# Altium schematic sheet Loads.SchDoc
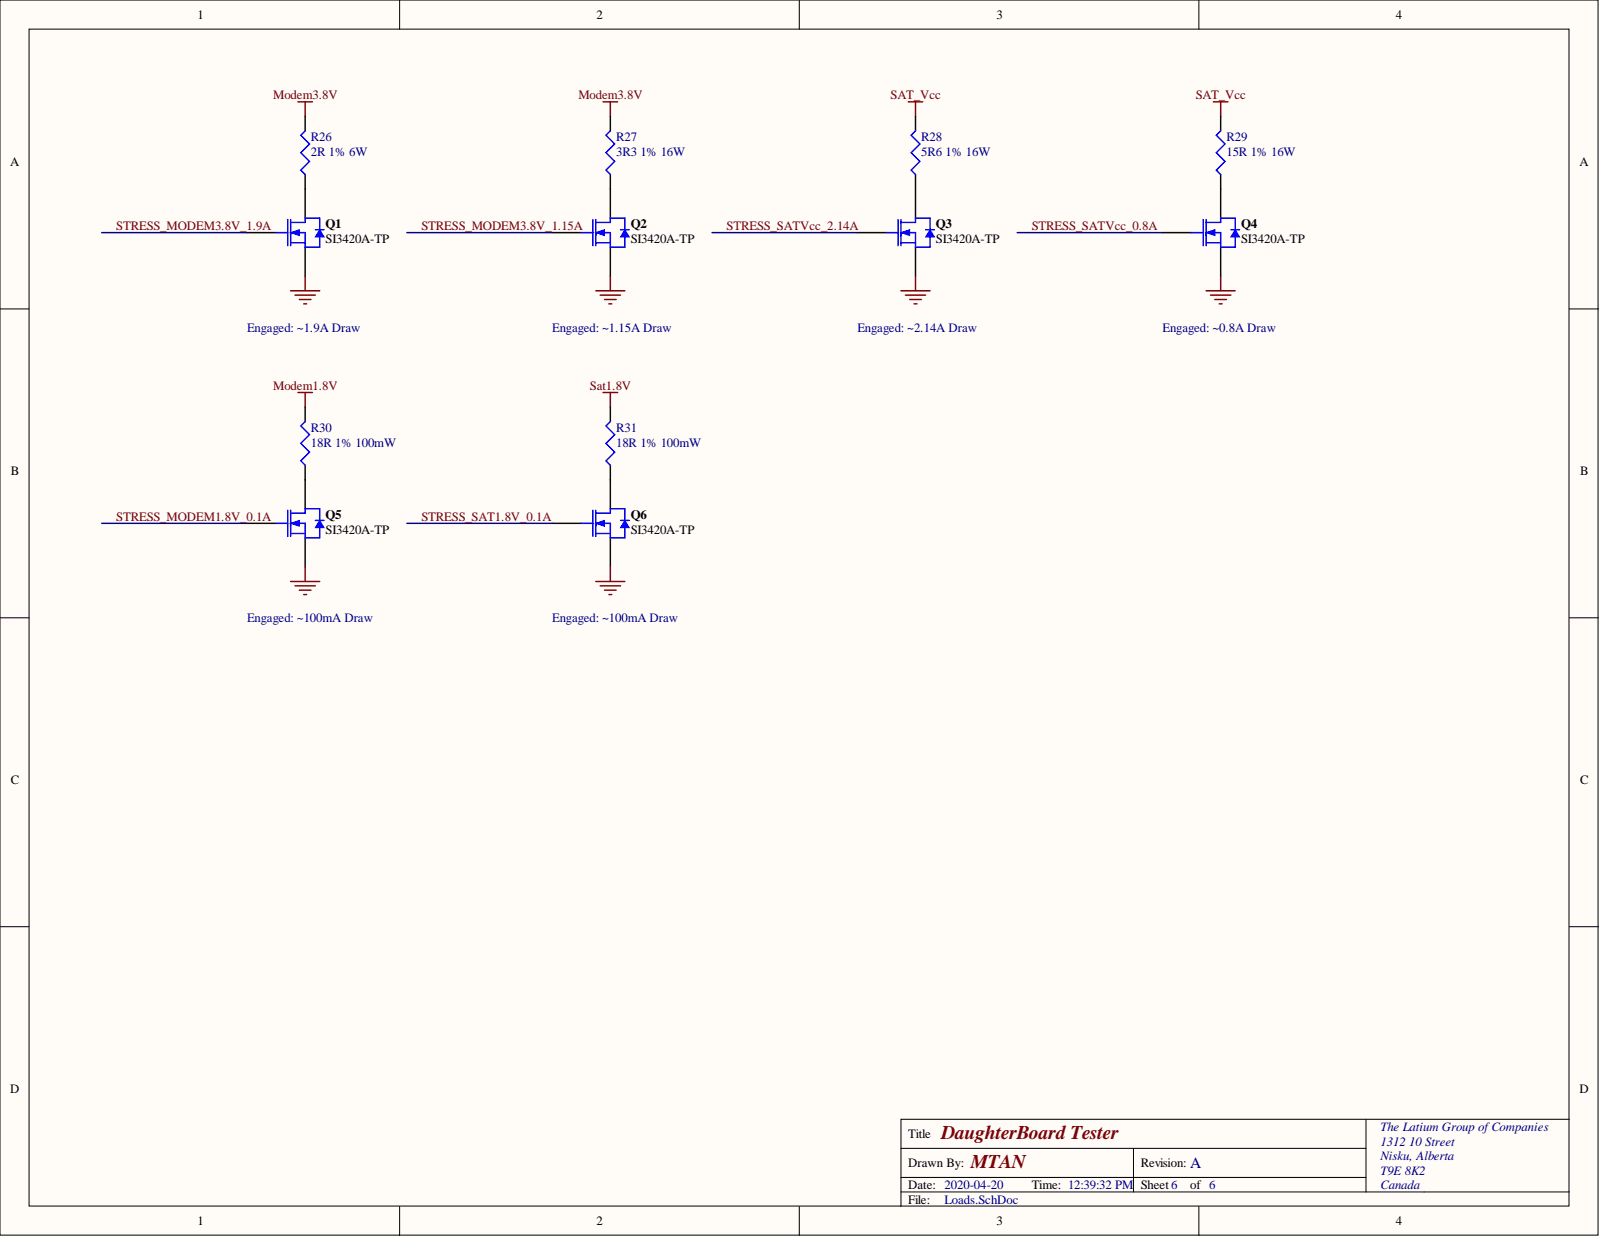
[diagram p0: Loads.SchDoc]

## Parts
Q1  SI3420A-TP  [INF-PG-SOT23_L]
Q2  SI3420A-TP  [INF-PG-SOT23_L]
Q3  SI3420A-TP  [INF-PG-SOT23_L]
Q4  SI3420A-TP  [INF-PG-SOT23_L]
Q5  SI3420A-TP  [INF-PG-SOT23_L]
Q6  SI3420A-TP  [INF-PG-SOT23_L]
R26  2R 1% 6W  [RESC6432AL]
R27  3R3 1% 16W  [RESC6432AL]
R28  5R6 1% 16W  [RESC6432AL]
R29  15R 1% 16W  [RESC6432AL]
R30  18R 1% 100mW  [J1-0603]
R31  18R 1% 100mW  [J1-0603]

## Nets
GND: Q1.2 Q2.2 Q3.2 Q4.2 Q5.2 Q6.2
Modem1.8V: R30.2
Modem3.8V: R26.2 R27.2
Sat1.8V: R31.2
SAT_Vcc: R28.2 R29.2
STRESS_MODEM1.8V_0.1A: Q5.1
STRESS_MODEM3.8V_1.9A: Q1.1
STRESS_MODEM3.8V_1.15A: Q2.1
STRESS_SAT1.8V_0.1A: Q6.1
STRESS_SATVcc_0.8A: Q4.1
STRESS_SATVcc_2.14A: Q3.1
NetQ1_3: Q1.3 R26.1
NetQ2_3: Q2.3 R27.1
NetQ3_3: Q3.3 R28.1
NetQ4_3: Q4.3 R29.1
NetQ5_3: Q5.3 R30.1
NetQ6_3: Q6.3 R31.1

## Library data of the parts
Q1-Q6 = 2N7002: MOSFET N-CH 20V 6A SOT-23; Manufacturer: Micro Commercial Co; Component Kind: Standard; Library Name: Infineon Power MOSFET.SchLib; Manufacturer Part Number: SI3420A-TP; Supplier 1: Digi-Key; Supplier 1 Part Number: SI3420A-TPMSCT-ND; Value: SI3420A-TP
R26 = Res3: RES SMD 2 OHM 1% 6W 2512; Manufacturer: Vishay Thin Film; Manufacturer Part Number: PCNM2512K2R00FST5; Component Kind: Standard; Library Name: Miscellaneous Devices.SchLib; Supplier 1: Digi-Key; Supplier 1 Part Number: 764-1271-1-ND; Value: 2R 1% 6W
R27 = Res3: RES SMD 3.3 OHM 1% 16W 2512; Manufacturer: Susumu; Manufacturer Part Number: CPA2512Q3R30FS-T10; Component Kind: Standard; Library Name: Miscellaneous Devices.SchLib; Supplier 1: Digi-Key; Supplier 1 Part Number: CPA25Q3.3CT-ND; Value: 3R3 1% 16W
R28 = Res3: RES SMD 5.6 OHM 1% 16W 2512; Manufacturer: Susumu; Manufacturer Part Number: CPA2512Q5R60FS-T10; Component Kind: Standard; Library Name: Miscellaneous Devices.SchLib; Supplier 1: Digi-Key; Supplier 1 Part Number: CPA25Q5.6CT-ND; Value: 5R6 1% 16W
R29 = Res3: RES SMD 15 OHM 1% 16W 2512; Manufacturer: Susumu; Manufacturer Part Number: CPA2512Q15R0FS-T10; Component Kind: Standard; Library Name: Miscellaneous Devices.SchLib; Supplier 1: Digi-Key; Supplier 1 Part Number: CPA25Q15.0CT-ND; Value: 15R 1% 16W
R30 R31 = Res3: Resistor; Component Kind: Standard; Library Name: Miscellaneous Devices.SchLib; Value: 18R 1% 100mW
